# Altium schematic sheet 4-USB & SATA.SchDoc
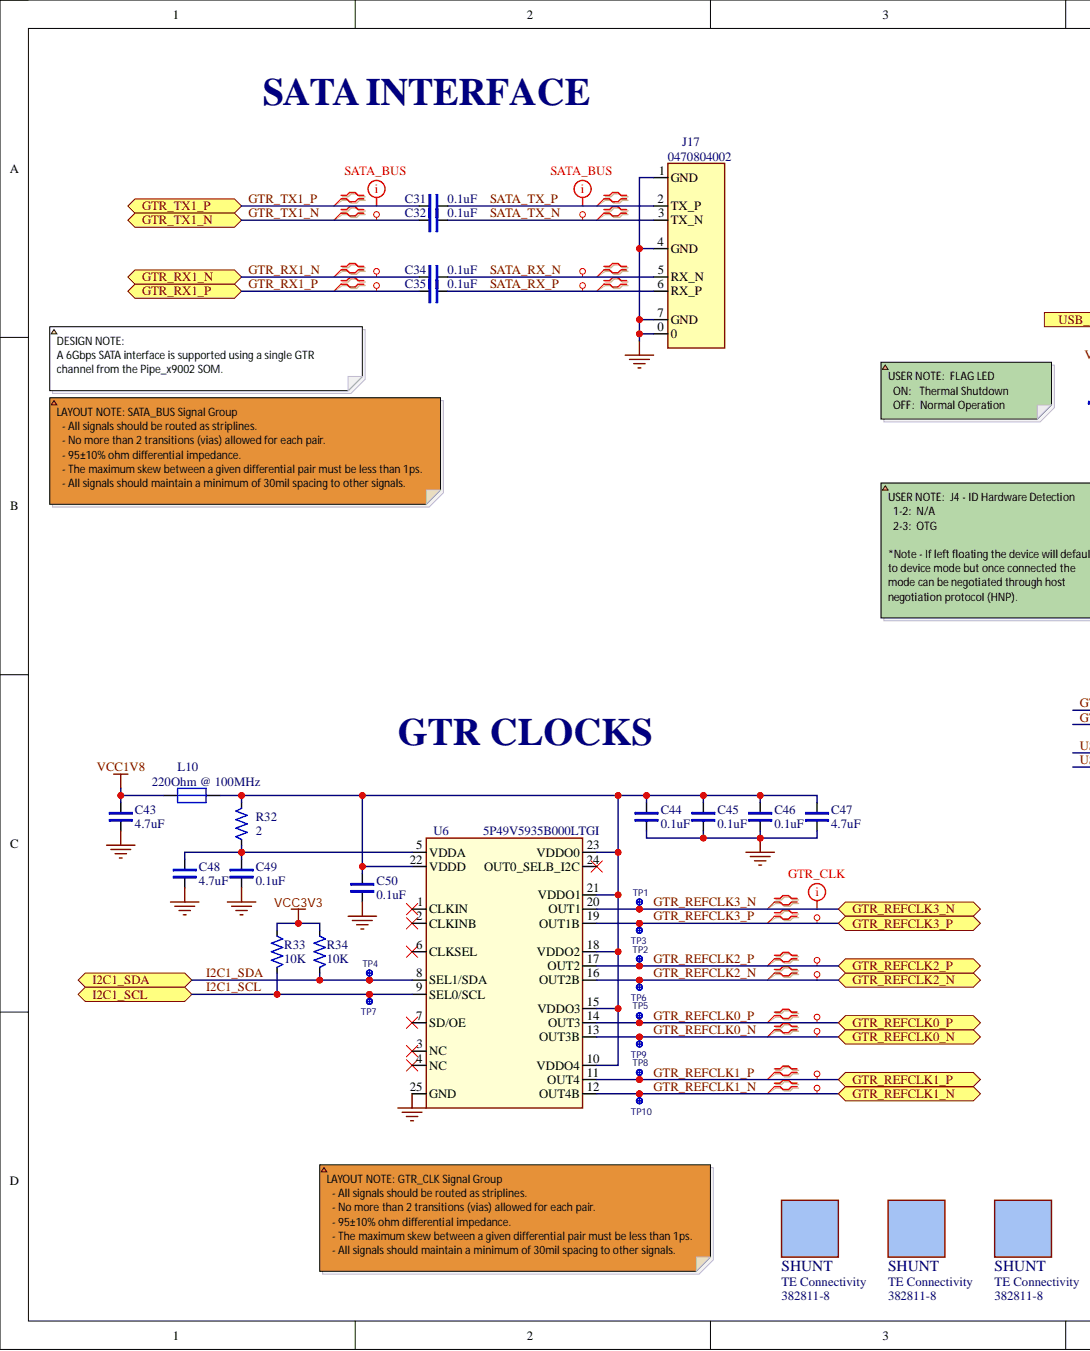
[diagram p0: 4-USB & SATA.SchDoc, left]
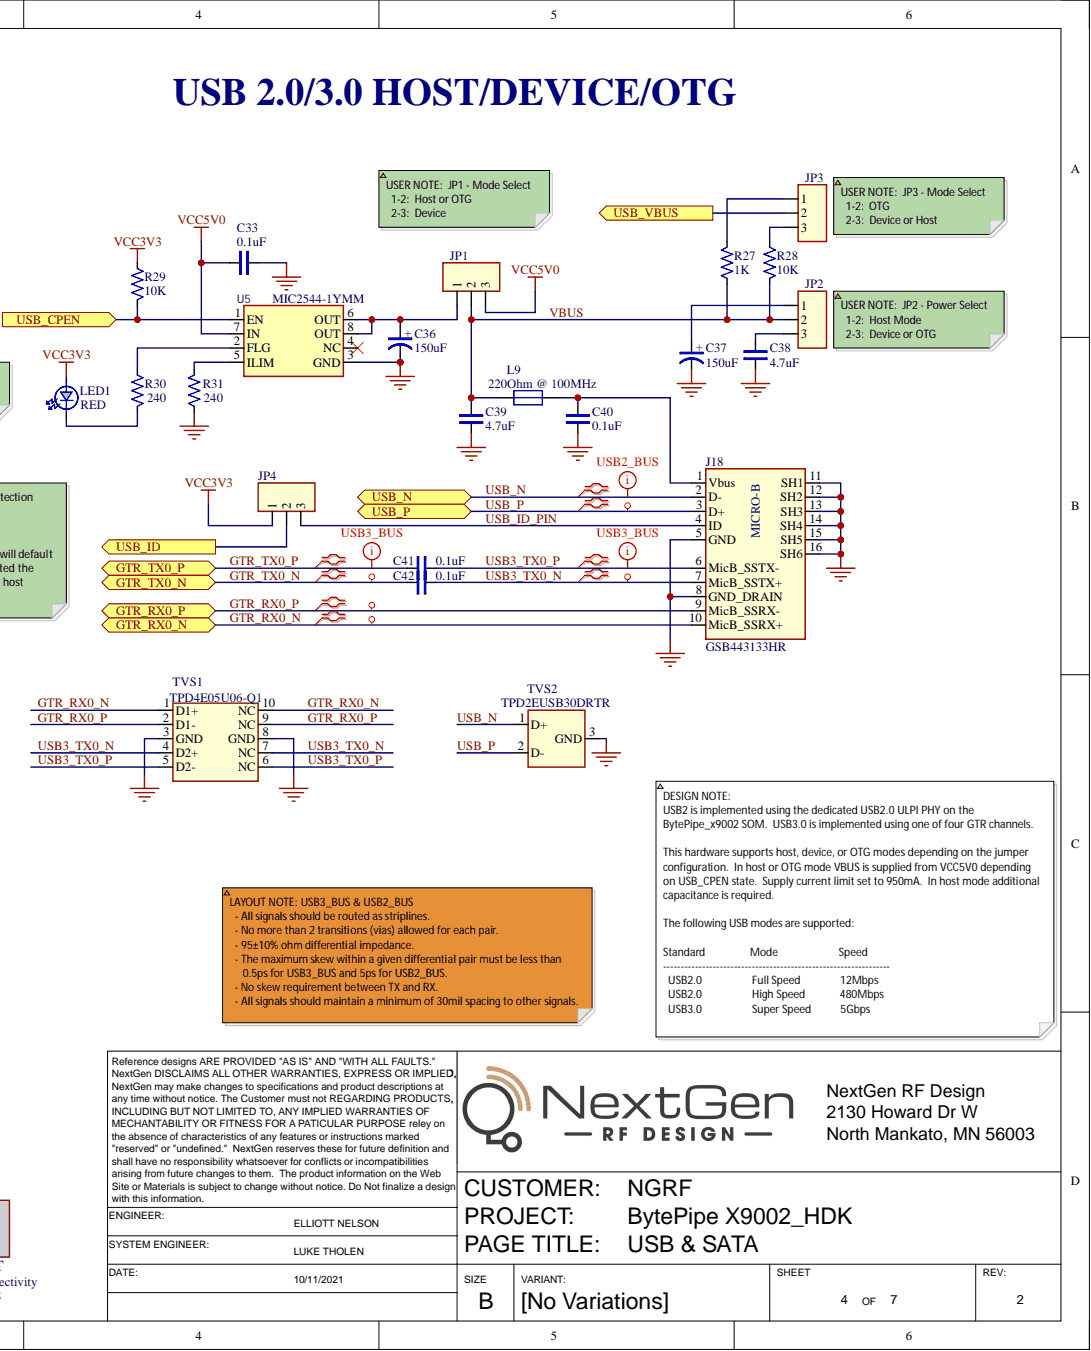
[diagram p1: 4-USB & SATA.SchDoc, right]

## Parts
C31  value 0.1uF  [SM/0402/CAP]  (hidden comment: CAP_CERAMIC_0402)
C32  value 0.1uF  [SM/0402/CAP]  (hidden comment: CAP_CERAMIC_0402)
C33  value 0.1uF  [SM/0402/CAP]  (hidden comment: CAP_CERAMIC_0402)
C34  value 0.1uF  [SM/0402/CAP]  (hidden comment: CAP_CERAMIC_0402)
C35  value 0.1uF  [SM/0402/CAP]  (hidden comment: CAP_CERAMIC_0402)
C36  value 150uF  [SM/CAP/TANT-D_A]  (hidden comment: TANT-D)
C37  value 150uF  [SM/CAP/TANT-D_A]  (hidden comment: TANT-D)
C38  value 4.7uF  [SM/0402/CAP]  (hidden comment: CAP_CERAMIC_0402)
C39  value 4.7uF  [SM/0402/CAP]  (hidden comment: CAP_CERAMIC_0402)
C40  value 0.1uF  [SM/0402/CAP]  (hidden comment: CAP_CERAMIC_0402)
C41  value 0.1uF  [SM/0402/CAP]  (hidden comment: CAP_CERAMIC_0402)
C42  value 0.1uF  [SM/0402/CAP]  (hidden comment: CAP_CERAMIC_0402)
C43  value 4.7uF  [SM/0402/CAP]  (hidden comment: CAP_CERAMIC_0402)
C44  value 0.1uF  [SM/0402/CAP]  (hidden comment: CAP_CERAMIC_0402)
C45  value 0.1uF  [SM/0402/CAP]  (hidden comment: CAP_CERAMIC_0402)
C46  value 0.1uF  [SM/0402/CAP]  (hidden comment: CAP_CERAMIC_0402)
C47  value 4.7uF  [SM/0402/CAP]  (hidden comment: CAP_CERAMIC_0402)
C48  value 4.7uF  [SM/0402/CAP]  (hidden comment: CAP_CERAMIC_0402)
C49  value 0.1uF  [SM/0402/CAP]  (hidden comment: CAP_CERAMIC_0402)
C50  value 0.1uF  [SM/0402/CAP]  (hidden comment: CAP_CERAMIC_0402)
J17  value 0470804002  OEM#: 0470804002  [SM/CON/MLX/SATA/0470804002]  (hidden comment: CON_MOLEX_0470804002)
J18  value GSB443133HR  OEM#: GSB443133HR  [SM/CON/USB3.1/MICRO B]  (hidden comment: CON_USB_3.1_MICRO-B_RA)
JP1  CON_100SP_1X3P_038H  [CON/100SP/1X3P]  (value not drawn)
JP2  CON_100SP_1X3P_038H  [CON/100SP/1X3P]  (value not drawn)
JP3  CON_100SP_1X3P_038H  [CON/100SP/1X3P]  (value not drawn)
JP4  CON_100SP_1X3P_038H  [CON/100SP/1X3P]  (value not drawn)
L9  value 220Ohm @ 100MHz  [SM/0603]  (hidden comment: FERRITE_0603_A)
L10  value 220Ohm @ 100MHz  [SM/0603]  (hidden comment: FERRITE_0603_A)
LED1  value RED  [SM/LED_0603]  (hidden comment: LED_0603)
R27  value 1K  [SM/0402/RES]  (hidden comment: RES_0402_A)
R28  value 10K  [SM/0402/RES]  (hidden comment: RES_0402_A)
R29  value 10K  [SM/0402/RES]  (hidden comment: RES_0402_A)
R30  value 240  [SM/0402/RES]  (hidden comment: RES_0402_A)
R31  value 240  [SM/0402/RES]  (hidden comment: RES_0402_A)
R32  value 2  [SM/0402/RES]  (hidden comment: RES_0402_A)
R33  value 10K  [SM/0402/RES]  (hidden comment: RES_0402_A)
R34  value 10K  [SM/0402/RES]  (hidden comment: RES_0402_A)
S1  SHUNT  OEM NAME: TE Connectivity
S2  SHUNT  OEM NAME: TE Connectivity
S3  SHUNT  OEM NAME: TE Connectivity
TP1  TESTPOINT_030  [SM/TESTPOINT/30MIL]  (value not drawn)  (no BOM)
TP2  TESTPOINT_030  [SM/TESTPOINT/30MIL]  (value not drawn)  (no BOM)
TP3  TESTPOINT_030  [SM/TESTPOINT/30MIL]  (value not drawn)  (no BOM)
TP4  TESTPOINT_030  [SM/TESTPOINT/30MIL]  (value not drawn)  (no BOM)
TP5  TESTPOINT_030  [SM/TESTPOINT/30MIL]  (value not drawn)  (no BOM)
TP6  TESTPOINT_030  [SM/TESTPOINT/30MIL]  (value not drawn)  (no BOM)
TP7  TESTPOINT_030  [SM/TESTPOINT/30MIL]  (value not drawn)  (no BOM)
TP8  TESTPOINT_030  [SM/TESTPOINT/30MIL]  (value not drawn)  (no BOM)
TP9  TESTPOINT_030  [SM/TESTPOINT/30MIL]  (value not drawn)  (no BOM)
TP10  TESTPOINT_030  [SM/TESTPOINT/30MIL]  (value not drawn)  (no BOM)
TVS1  value TPD4E05U06-Q1  OEM#: TPD4E05U06-Q1  [SM/DFN10/2.5X1MM_A]  (hidden comment: TVS_TPD4E05U06_2.5mmX1mm)
TVS2  value TPD2EUSB30DRTR  OEM#: TPD2EUSB30DRTR  [SM/SOT3_A]  (hidden comment: TVS_TPD2EUSB30DRTR_SOT3)
U5  value MIC2544-1YMM  OEM#: MIC2544-1YMM  [SM/MSOP8_A]  (hidden comment: IC_MIC2544-1YMM_MSOP-8)
U6  value 5P49V5935B000LTGI  OEM#: 5P49V5935B000LTGI  [SM/LGA24/4X4/0.5LS_A]  (hidden comment: IC_5P49V5935_LGA-24)

## Ports
GTR_REFCLK0_N, GTR_REFCLK0_P, GTR_REFCLK1_N, GTR_REFCLK1_P, GTR_REFCLK2_N, GTR_REFCLK2_P, GTR_REFCLK3_N, GTR_REFCLK3_P, GTR_RX0_N, GTR_RX0_P, GTR_RX1_N, GTR_RX1_P, GTR_TX0_N, GTR_TX0_P, GTR_TX1_N, GTR_TX1_P, I2C1_SCL, I2C1_SDA, USB_CPEN, USB_ID, USB_N, USB_P, USB_VBUS

## Nets
GND: C33.2 C36.2 C37.2 C38.2 C39.2 C40.2 C43.2 C44.2 C45.2 C46.2 C47.2 C48.2 C49.2 C50.2 J17.0 J17.1(GND) J17.4(GND) J17.7(GND) J18.5(GND) J18.8(GND_DRAIN) J18.11(SH1) J18.12(SH2) J18.13(SH3) J18.14(SH4) J18.15(SH5) J18.16(SH6) R31.2 TVS1.3(GND) TVS1.8(GND) TVS2.3 U5.3(GND) U6.25(GND)
VCC1V8: C43.1 L10.1
VCC3V3: JP4.1 LED1.2 R29.1 R33.1 R34.1
VCC5V0: C33.1 JP1.3 U5.7(IN)
GTR_REFCLK0_N: TP9.1 U6.13(OUT3B) ; port GTR_REFCLK0_N
GTR_REFCLK0_P: TP5.1 U6.14(OUT3) ; port GTR_REFCLK0_P
GTR_REFCLK1_N: TP10.1 U6.12(OUT4B) ; port GTR_REFCLK1_N
GTR_REFCLK1_P: TP8.1 U6.11(OUT4) ; port GTR_REFCLK1_P
GTR_REFCLK2_N: TP6.1 U6.16(OUT2B) ; port GTR_REFCLK2_N
GTR_REFCLK2_P: TP2.1 U6.17(OUT2) ; port GTR_REFCLK2_P
GTR_REFCLK3_N: TP1.1 U6.20(OUT1) ; port GTR_REFCLK3_N
GTR_REFCLK3_P: TP3.1 U6.19(OUT1B) ; port GTR_REFCLK3_P
GTR_RX0_N: J18.10(MicB_SSRX+) TVS1.1(D1+) TVS1.10(NC) ; port GTR_RX0_N
GTR_RX0_P: J18.9(MicB_SSRX-) TVS1.2(D1-) TVS1.9(NC) ; port GTR_RX0_P
GTR_RX1_N: C34.1 ; port GTR_RX1_N
GTR_RX1_P: C35.1 ; port GTR_RX1_P
GTR_TX0_N: C42.1 ; port GTR_TX0_N
GTR_TX0_P: C41.1 ; port GTR_TX0_P
GTR_TX1_N: C32.1 ; port GTR_TX1_N
GTR_TX1_P: C31.1 ; port GTR_TX1_P
I2C1_SCL: R33.2 TP7.1 U6.9(SEL0/SCL) ; port I2C1_SCL
I2C1_SDA: R34.2 TP4.1 U6.8(SEL1/SDA) ; port I2C1_SDA
SATA_RX_N: C34.2 J17.5(RX_N)
SATA_RX_P: C35.2 J17.6(RX_P)
SATA_TX_N: C32.2 J17.3(TX_N)
SATA_TX_P: C31.2 J17.2(TX_P)
USB3_TX0_N: C42.2 J18.7(MicB_SSTX+) TVS1.4(D2+) TVS1.7(NC)
USB3_TX0_P: C41.2 J18.6(MicB_SSTX-) TVS1.5(D2-) TVS1.6(NC)
USB_CPEN: R29.2 U5.1(EN) ; port USB_CPEN
USB_ID: JP4.2 ; port USB_ID
USB_ID_PIN: J18.4(ID) JP4.3
USB_N: J18.2(D-) TVS2.1 ; port USB_N
USB_P: J18.3(D+) TVS2.2 ; port USB_P
USB_VBUS: JP3.2 ; port USB_VBUS
VBUS: C39.1 JP1.2 JP2.2 L9.1 R27.1 R28.2
NetC36_1: C36.1 JP1.1 U5.6(OUT) U5.8(OUT)
NetC37_1: C37.1 JP2.1
NetC38_1: C38.1 JP2.3
NetC40_1: C40.1 J18.1(Vbus) L9.2
NetC44_1: C44.1 C45.1 C46.1 C47.1 C50.1 L10.2 R32.1 U6.10(VDDO4) U6.15(VDDO3) U6.18(VDDO2) U6.21(VDDO1) U6.22(VDDD) U6.23(VDDO0)
NetC48_1: C48.1 C49.1 R32.2 U6.5(VDDA)
NetJP3_1: JP3.1 R27.2
NetJP3_3: JP3.3 R28.1
NetLED1_1: LED1.1 R30.1
NetR30_2: R30.2 U5.2(FLG)
NetR31_1: R31.1 U5.5(ILIM)

Not connected: U5 4(NC); U6 1(CLKIN) 2(CLKINB) 3(NC) 4(NC) 6(CLKSEL) 7(SD/OE) 24(OUT0_SELB_I2C)

## Library data of the parts
C31-C35 C40-C42 C44-C46 C49 C50 = CAP_CERAMIC_0402: CAP CER 0.1UF 25V X7R 0402; OEM NAME: Samsung; OEM#: CL05B104KA5NNNC; TOL: ±10%; Component Kind: Standard; VOLT: 25V; Library Name: NextGenRF_Symbols_SMT.SchLib
C36 C37 = CAP_TANT-D: CAP TANT 150UF 20% 10V 2917; OEM NAME: KEMET; OEM#: T491D157M010AT; Component Kind: Standard; VOLTS: 10V; Library Name: NextGenRF_Symbols_SMT.SchLib
C38 C39 C43 C47 C48 = CAP_CERAMIC_0402: CAP CER 4.7UF 10V X5R 0402; OEM NAME: Samsung; OEM#: CL05A475MP5NRNC; TOL: ±20%; Component Kind: Standard; VOLT: 10V; Library Name: NextGenRF_Symbols_SMT.SchLib
J17 = CON_MOLEX_0470804002: 7 Position SATA Plug Connector Solder Surface Mount, Right Angle; OEM NAME: MOLEX; Component Kind: Standard; Library Name: NextGenRF_Symbols_SMT.SchLib
J18 = (no symbol name): CONN RCPT USB3.1 MICRO B SMD R/A; OEM NAME: Amphenol ICC; Component Kind: Standard; Library Name: NextGenRF_Symbols_SMT.SchLib
JP1-JP4 = CON_100SP_1X3P_038H: PIN HEADER, SINGLE ROW 3 PIN STR; OEM NAME: Adam Tech; OEM#: PH1-03-UA; VALUE: PH1-03-UA; Component Kind: Standard; Library Name: NextGenRF_Symbols_TH.SchLib
L9 L10 = FERRITE_0603_A: 120 Ohms @ 100MHz 1 Power Line Ferrite Bead 0603 (1608 Metric) 3A 25mOhm; OEM NAME: Murata Electronics; OEM#: BLM18SG121TN1D; Component Kind: Standard; Library Name: NextGenRF_Symbols_SMT.SchLib
LED1 = LED_0603: LED-0603; OEM NAME: OSRAM; OEM#: LS Q976-NR-1; Component Kind: Standard; Library Name: NextGenRF_Symbols_SMT.SchLib
R27 = RES_0402_A: RES SMD 1K OHM 1% 1/10W 0402; OEM NAME: Panasonic; OEM#: ERJ-2RKF1001X; Component Kind: Standard; TOL: ±1%; Library Name: NextGenRF_Symbols_SMT.SchLib
R28 R29 R33 R34 = RES_0402_A: OEM NAME: Panasonic; OEM#: ERJ-2RKF1002X; TOL: ±1%; Component Kind: Standard; Library Name: NextGenRF_Symbols_SMT.SchLib
R30 R31 = RES_0402_A: RES SMD 240 OHM 1% 1/10W 0402; OEM NAME: Panasonic; OEM#: ERJ-2RKF2400X; Component Kind: Standard; TOL: 1%; Library Name: NextGenRF_Symbols_SMT.SchLib
R32 = RES_0402_A: RES 2 OHM 1% 1/10W 0402 SMD; OEM NAME: Panasonic; OEM#: ERJ-U02F2R00X; Component Kind: Standard; TOL: ±1%; Library Name: NextGenRF_Symbols_SMT.SchLib
S1-S3 = BOM_COMPONENT_NO-FOOTPRINT: OEM#: 382811-8; Component Kind: Standard; Description: SHUNT; VALUE: SHUNT; Library Name: NextGenRF_Symbols_MECH.SchLib
TVS1 = TVS_TPD4E05U06_2.5mmX1mm: TVS DIODE 5.5V 14V 10SON; OEM NAME: TI; Component Kind: Standard; Library Name: NextGenRF_Symbols_SMT.SchLib
TVS2 = TVS_TPD2EUSB30DRTR_SOT3: TVS DIODE 5.5V 8V SOT3; OEM NAME: Texas Instruments; WATT: 45; Component Kind: Standard; Library Name: NextGenRF_Symbols_SMT.SchLib
U5 = IC_MIC2544-1YMM_MSOP-8: Power Switch/Driver 1:1 N-Channel 1.5A 8-MSOP; OEM NAME: MICROCHIP; Component Kind: Standard; Library Name: NextGenRF_Symbols_SMT.SchLib
U6 = IC_5P49V5935_LGA-24: IC Programable Clock Generator; OEM NAME: Renesas; Component Kind: Standard; Library Name: NextGenRF_Symbols_SMT.SchLib
TP1-TP10 = TESTPOINT_030: Library Name: NextGenRF_Symbols_SMT.SchLib
